# Altium schematic sheet PVDFReader_main.SchDoc
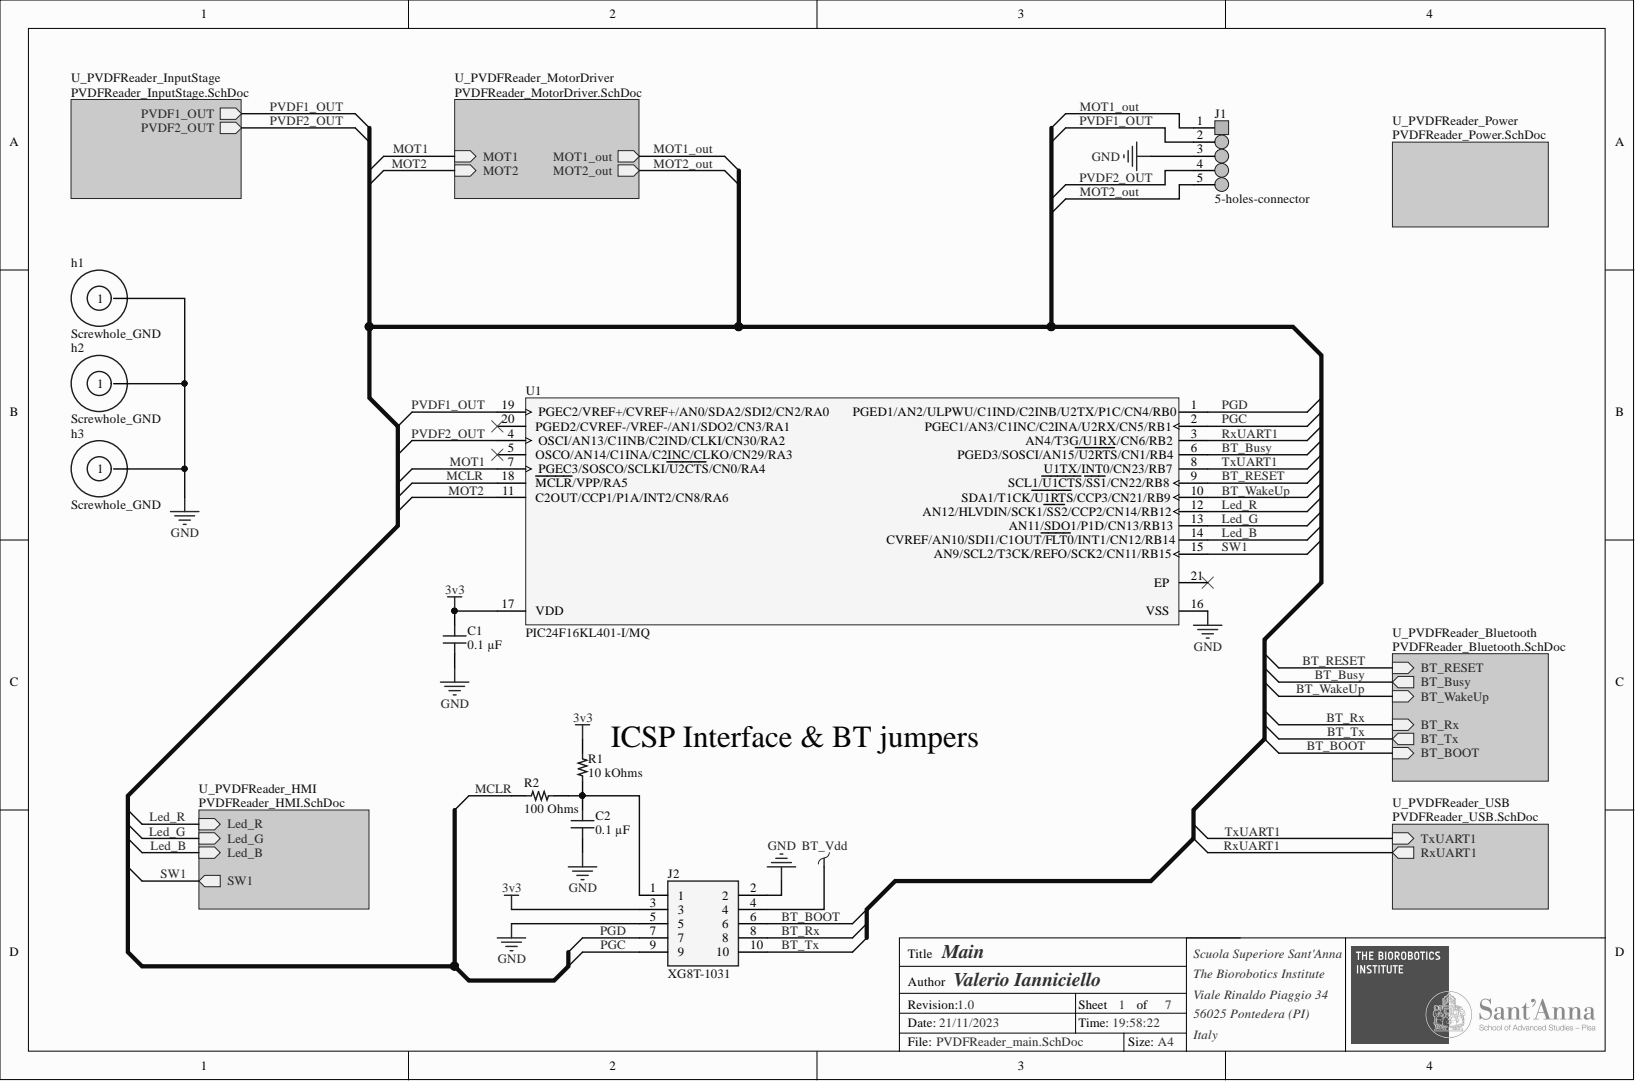
[diagram p0: PVDFReader_main.SchDoc]

## Parts
C1  0.1 µF  [CAP 0603_1608]
C2  0.1 µF  [CAP 0603_1608]
h1  Screwhole_GND  [Screwhole_M2_GND_v2]
h2  Screwhole_GND  [Screwhole_M2_GND_v2]
h3  Screwhole_GND  [Screwhole_M2_GND_v2]
J1  5-holes-connector  [5-holes-connector]
J2  XG8T-1031  [HDRV10W64P254_2X5_1270X500X680P]
R1  10 kOhms  [RES 0603_1608]
R2  100 Ohms  [RES 0603_1608]
U1  PIC24F16KL401-I/MQ  [QFN65P500X500X100-21N-D]  (value not drawn)

## Nets
3v3: C1.2 J2.3 R1.2 U1.17(VDD)
BT_Vdd: J2.4
GND: C1.1 C2.1 h1.1 h2.1 h3.1 J1.3 J2.2 J2.5 U1.16(VSS)
BT_BOOT: J2.6
BT_Busy: U1.6(PGED3/SOSCI/AN15/U2RTS/CN1/RB4)
BT_RESET: U1.9(SCL1/U1CTS/SS1/CN22/RB8)
BT_Rx: J2.8
BT_Tx: J2.10
BT_WakeUp: U1.10(SDA1/T1CK/U1RTS/CCP3/CN21/RB9)
Led_B: U1.14(CVREF/AN10/SDI1/C1OUT/FLT0/INT1/CN12/RB14)
Led_G: U1.13(AN11/SDO1/P1D/CN13/RB13)
Led_R: U1.12(AN12/HLVDIN/SCK1/SS2/CCP2/CN14/RB12)
MCLR: R2.1 U1.18(MCLR/VPP/RA5)
MOT1: U1.7(PGEC3/SOSCO/SCLKI/U2CTS/CN0/RA4)
MOT1_out: J1.1
MOT2: U1.11(C2OUT/CCP1/P1A/INT2/CN8/RA6)
MOT2_out: J1.5
PGC: J2.9 U1.2(PGEC1/AN3/C1INC/C2INA/U2RX/CN5/RB1)
PGD: J2.7 U1.1(PGED1/AN2/ULPWU/C1IND/C2INB/U2TX/P1C/CN4/RB0)
PVDF1_OUT: J1.2 U1.19(PGEC2/VREF+/CVREF+/AN0/SDA2/SDI2/CN2/RA0)
PVDF2_OUT: J1.4 U1.4(OSCI/AN13/C1INB/C2IND/CLKI/CN30/RA2)
RxUART1: U1.3(AN4/T3G/U1RX/CN6/RB2)
SW1: U1.15(AN9/SCL2/T3CK/REFO/SCK2/CN11/RB15)
TxUART1: U1.8(U1TX/INT0/CN23/RB7)
NetC2_2: C2.2 J2.1 R1.1 R2.2
NetU1_5: U1.5(OSCO/AN14/C1INA/C2INC/CLKO/CN29/RA3)
NetU1_20: U1.20(PGED2/CVREF-/VREF-/AN1/SDO2/CN3/RA1)
NetU1_21: U1.21(EP)
N00018:

## Library data of the parts
C1 C2 = C0603C104K4NACAUTO: AUTO-150C-(CXXXXC-AUTO), CERAMIC; manufacturer: KEMET; Database Table Name: Capacitors - Ceramic - 0603; Temperature Coefficient: X8L; Tolerance: ±10%; Voltage - Rated: 16V; Applications: Automotive; Capacitance: 0.1 µF; Component Kind: Standard; componentlink1description: Datasheet; componentlink1url: https://api.kemet.com/component-edge/download/datasheet/C0603C104K4NACAUTO.pdf; componentlink2description: DigiKey Link; componentlink2url: https://www.digikey.com/en/products/detail/kemet/C0603C104K4NACAUTO/10232816; Device Package: 0603 (1608 Metric); Features: High Temperature; lastupdated: 19/11/2023 20:10:36; Library Name: Celestial Altium Library - altium_library.DbLib; Lifecycle Status: Active; Minimum Order: 1; Mounting Type: Surface Mount, MLCC; Operating Temperature: -55°C ~ 150°C; Package / Case: 0603 (1608 Metric); packaging: TapeAndReel; partid: 3823; Part Number: C0603C104K4NACAUTO; Part Status: Active; price: 0.01; Ratings: AEC-Q200; series: SMD Auto X8L HT150C; Size / Dimension: 0.063" L x 0.031" W (1.60mm x 0.80mm); Supplier 1: DigiKey; Supplier Part Number 1: 399-C0603C104K4NACAUTOCT-ND; Thickness (Max): 0.034" (0.87mm); Value: 0.1 µF
h1-h3 = Screwhole_GND: Screwhole; Component Kind: Standard; Library Name: Connectors.IntLib
J2 = XG8T-1031: Connector; Manufacturer_Name: Omron Electronics; Component Kind: Standard; Datasheet Link: https://componentsearchengine.com/Datasheets/1/XG8T-1031.pdf; Height: 6.8mm; Manufacturer_Part_Number: XG8T-1031; Mouser Part Number: 653-XG8T-1031; Mouser Price/Stock: https://www.mouser.com/Search/Refine.aspx?Keyword=653-XG8T-1031
R1 = SG73P1JTTD103J: RES 10K OHM 5% 1/3W 0603; Manufacturer: KOA Speer Electronics, Inc.; Database Table Name: Resistors - Surface Mount - 0603; Power (Watts): 0.333W, 1/3W; Temperature Coefficient: ±100ppm/°C; Component Kind: Standard; ComponentLink1Description: Datasheet; ComponentLink1URL: https://www.koaspeer.com/pdfs/SG73P.pdf; ComponentLink2Description: DigiKey Link; ComponentLink2URL: https://www.digikey.com/en/products/detail/koa-speer-electronics-inc/SG73P1JTTD103J/10189959; Composition: Thick Film; Device Package: 0603 (1608 Metric); Features: Automotive AEC-Q200, Pulse Withstanding; Height - Seated (Max): 0.022" (0.55mm); LastUpdated: 19/11/2023 20:40:20; Library Name: Celestial Altium Library - altium_library.DbLib; Lifecycle Status: Active; Minimum Order: 1; Number of Terminations: 2; Operating Temperature: -55°C ~ 155°C; Package / Case: 0603 (1608 Metric); Packaging: TapeAndReel; PartId: 70929; Part Number: SG73P1JTTD103J; Part Status: Active; Price: 0.01; Ratings: AEC-Q200; Resistance: 10 kOhms; Series: SG73P; Size / Dimension: 0.063" L x 0.031" W (1.60mm x 0.80mm); Supplier 1: DigiKey; Supplier Device Package: 0603; Supplier Part Number 1: 2019-SG73P1JTTD103JCT-ND; Tolerance: ±5%; Value: 10 kOhms
R2 = RC0603JR-07100RL: RES 100 OHM 5% 1/10W 0603; Manufacturer: Yageo; Database Table Name: Resistors - Surface Mount - 0603; Power (Watts): 0.1W, 1/10W; Temperature Coefficient: ±100ppm/°C; Component Kind: Standard; ComponentLink1Description: Datasheet; ComponentLink1URL: https://www.yageo.com/upload/media/product/productsearch/datasheet/rchip/PYu-RC_Group_51_RoHS_L_10.pdf; ComponentLink2Description: DigiKey Link; ComponentLink2URL: https://www.digikey.com/en/products/detail/yageo/RC0603JR-07100RL/726697; Composition: Thick Film; Device Package: 0603 (1608 Metric); Features: Moisture Resistant; Height - Seated (Max): 0.022" (0.55mm); LastUpdated: 19/11/2023 20:41:48; Library Name: Celestial Altium Library - altium_library.DbLib; Lifecycle Status: Active; Minimum Order: 1; Number of Terminations: 2; Operating Temperature: -55°C ~ 155°C; Package / Case: 0603 (1608 Metric); Packaging: CutTape; PartId: 17071; Part Number: RC0603JR-07100RL; Part Status: Active; Price: 0.01; Resistance: 100 Ohms; Series: RC_L; Size / Dimension: 0.063" L x 0.031" W (1.60mm x 0.80mm); Supplier 1: DigiKey; Supplier Device Package: 0603; Supplier Part Number 1: 311-100GRCT-ND; Tolerance: ±5%; Value: 100 Ohms
U1 = PIC24F16KL401-I/MQ: Low-Cost General Purpose 16-Bit Flash Microcontroller with nanoWatt XLP Technology, 16 MIPS, 18 I/O, -40 to 85 degC, 20-pin QFN (MQ20), Tube; Manufacturer: Microchip; Codice Fornitore: 579-PIC24F16KL401IMQ; Fornitore: MOUSER; Component Kind: Standard; ComponentLink1Description: Manufacturer URL; ComponentLink1URL: http://www.microchip.com; ComponentLink2Description: Datasheet; ComponentLink2URL: http://ww1.microchip.com/downloads/en/DeviceDoc/31037b.pdf; ComponentLink3Description: Package Specification; ComponentLink3URL: http://www.microchip.com/stellent/groups/techpub_sg/documents/packagingspec/en012702.pdf; ComponentLink4Description: Product URL; ComponentLink4URL: http://www.microchip.com/PIC24F16KL401; DatasheetVersion: Rev. B; Library Name: IntegratedCircuits.IntLib; Mounting Technology: Surface Mount; PackageDescription: 20-Lead Plastic Quad Flat, No Lead Package, 5 x 5 mm Body, 0.65 mm Pitch; PackageReference: QFN-MQ20; PackageVersion: C04-139B; PartNumber: PIC24F16KL401-I/MQ; Supplier 1: Digi-Key; Supplier 2: Farnell; Supplier 3: Newark; Supplier 4: Newark; Supplier 5: Mouser; Supplier Part Number 1: PIC24F16KL401-I/MQ-ND; Supplier Part Number 2: 2079400; Supplier Part Number 3: 82T9611; Supplier Part Number 4: 64T1125; Supplier Part Number 5: 579-PIC24F16KL401IMQ
J1 = 5-holes-connector: Component Kind: Standard; Library Name: Connectors.IntLib
